# Altium schematic sheet 5.REMOTE.SchDoc
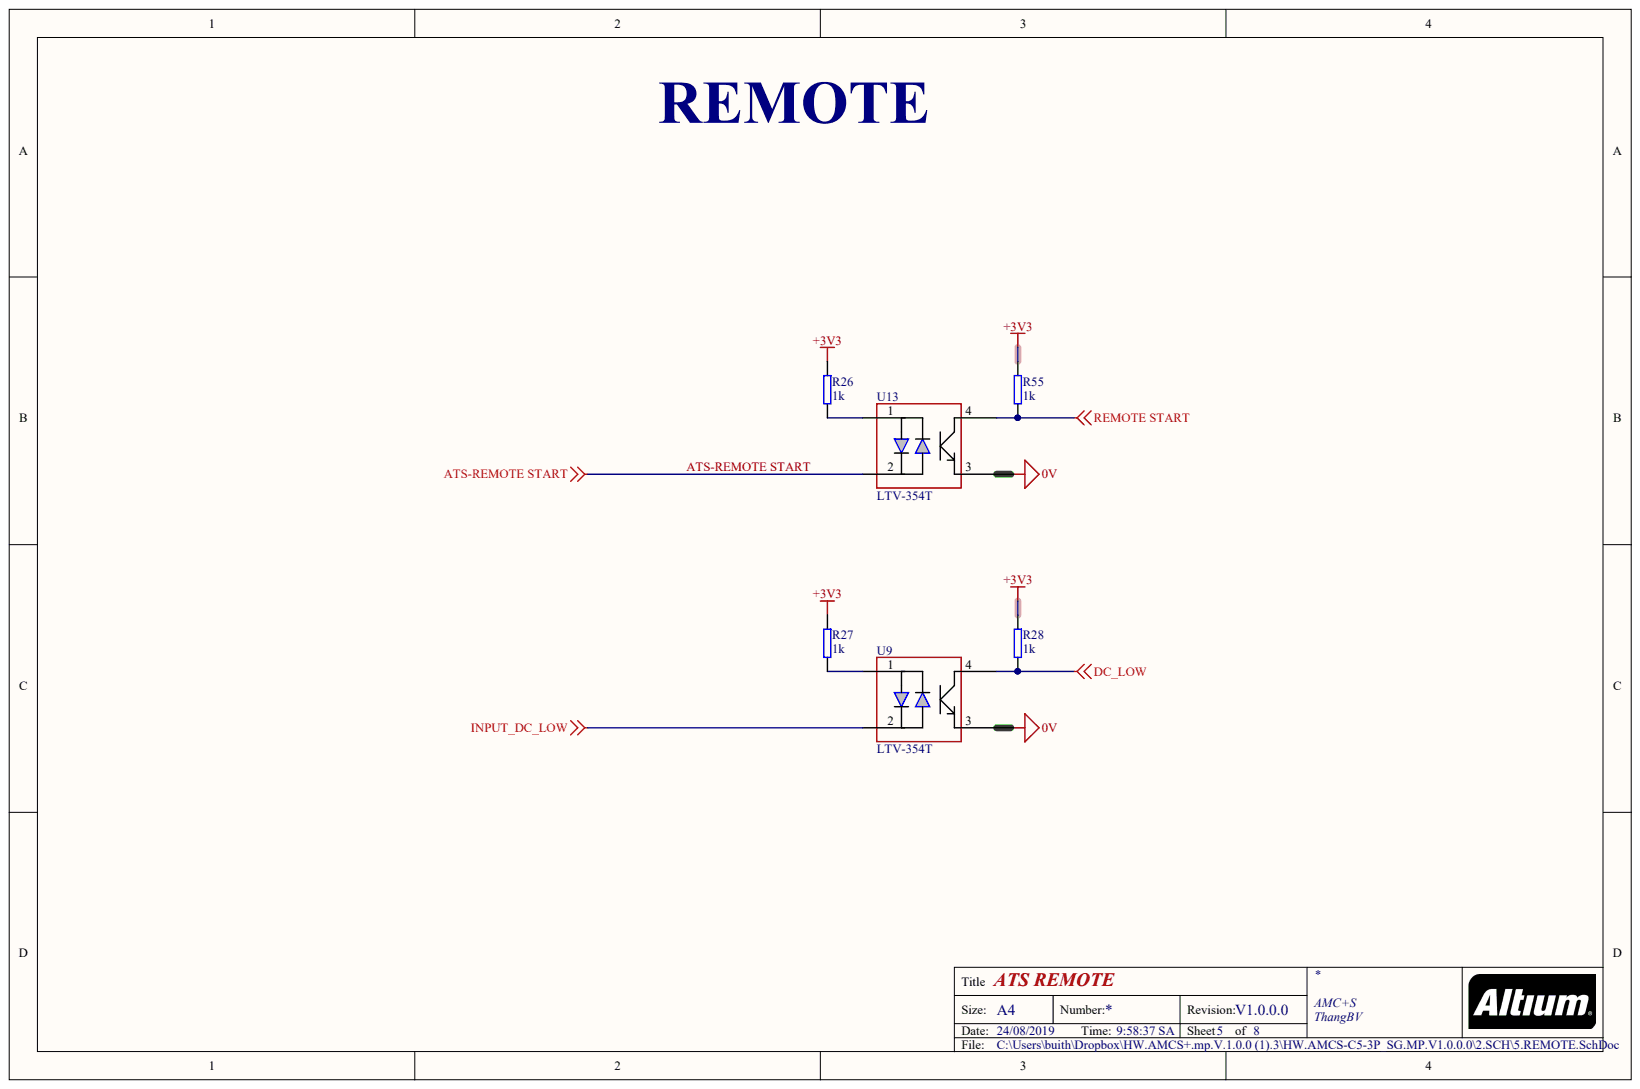
[diagram p0: 5.REMOTE.SchDoc]

## Parts
R26  1k  [0805]
R27  1k  [0805]
R28  1k  [0805]
R55  1k  [0805]
U9  LTV-354T  [SMD-4]
U13  LTV-354T  [SMD-4]

## Nets
0V: U9.3 U13.3
+3V3: R26.1 R27.1 R28.1 R55.1
DC_LOW: R28.2 U9.4
INPUT_DC_LOW: U9.2
REMOTE START: R55.2 U13.4
ATS-REMOTE START: U13.2
NetR26_2: R26.2 U13.1
NetR27_2: R27.2 U9.1

## Library data of the parts
R26-R28 R55 = Res_1k_0805: RES SMD 1K OHM 1% 1/8W 0805; MFR: Yageo; Part Number: RC0805FR-071KL; Vender PartNumber: 311-1.00KCRTR-ND; AMCS CODE: VT-RES-0003; ASS: SMT; Detailed Description: 1 kOhms ±1% 0.125W, 1/8W Chip Resistor 0805 (2012 Metric) Moisture Resistant Thick Film; Power (Watts): 0.125W, 1/8W; Resistance: 1 kOhms; Temperature Coefficient: ±100ppm/°C; Vender: Digi-Key; Component Kind: Standard; Operating Temperature: -55°C ~ 155°C; Package: 0805 (2012 Metric); Size / Dimension: 0.079" L x 0.049" W (2.00mm x 1.25mm); Tolerance: ±1%; TYPE: RES; Library Name: Schlib_Diepvn_V01.SchLib
U9 U13 = AC_OPTO: Optoisolator Transistor Output 3750Vrms 1 Channel 4-SOP (2.54mm); MFR: Lite-ON.Inc; AMCS CODE: VT-IC-0001; Component Kind: Standard; Package: 4-SOP (2.54mm); Part Number: LTV-354T; Library Name: Schlib_Diepvn_V01.SchLib
